# Altium schematic sheet B15.SchDoc(B15)
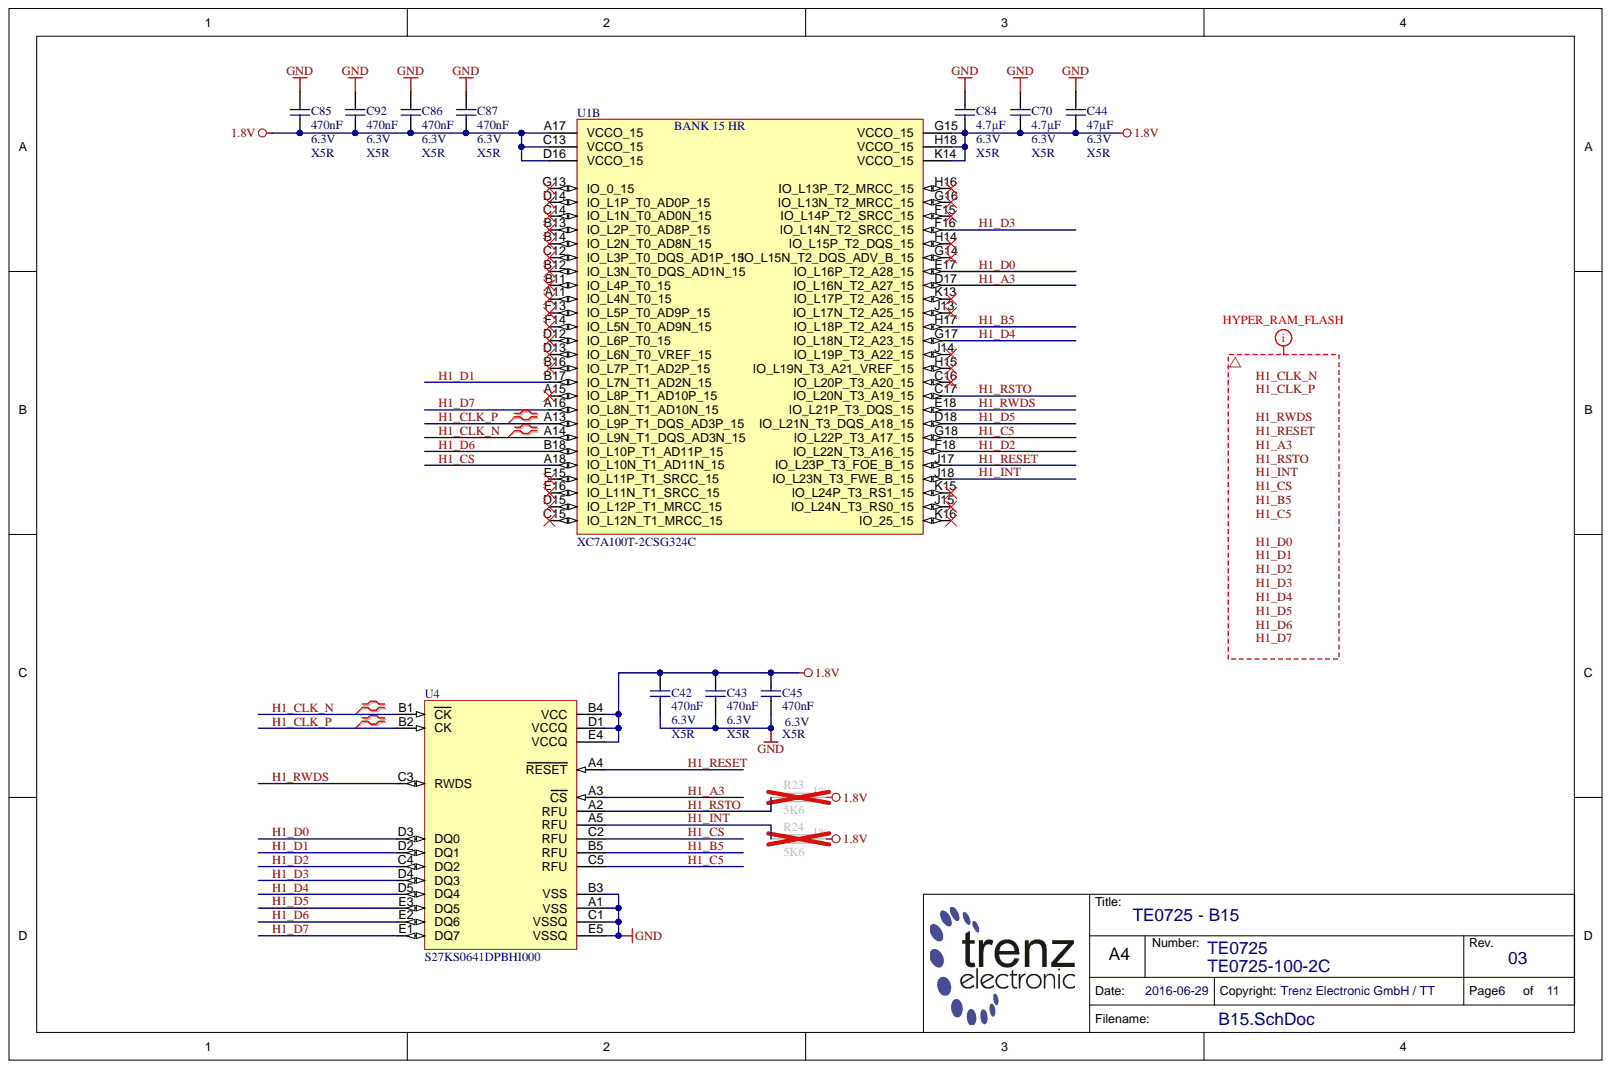
[diagram p0: B15.SchDoc]

## Parts
C42  470nF  Technology: X5R  Voltage: 6.3V  [CAPC0603X33N]
C43  470nF  Technology: X5R  Voltage: 6.3V  [CAPC0603X33N]
C44  47µF  Technology: X5R  Voltage: 6.3V  [CAPC2012X135N]
C45  470nF  Technology: X5R  Voltage: 6.3V  [CAPC0603X33N]
C70  4.7µF  Technology: X5R  Voltage: 6.3V  [CAPC1005X60N]
C84  4.7µF  Technology: X5R  Voltage: 6.3V  [CAPC1005X60N]
C85  470nF  Technology: X5R  Voltage: 6.3V  [CAPC0603X33N]
C86  470nF  Technology: X5R  Voltage: 6.3V  [CAPC0603X33N]
C87  470nF  Technology: X5R  Voltage: 6.3V  [CAPC0603X33N]
C92  470nF  Technology: X5R  Voltage: 6.3V  [CAPC0603X33N]
R23  5K6  Tolerance: 1%  [RESC1005X40N]
R24  5K6  Tolerance: 1%  [RESC1005X40N]
U1  XC7A100T-2CSG324C  [BGA324C80P18X18_1500X1500X150N]  (part B)
U4  S27KS0641DPBHI000  [BGA25C100P5X5_800X600X120N]

## Nets
1.8V: C42.1 C43.1 C44.2 C45.1 C70.2 C84.2 C85.2 C86.2 C87.2 C92.2 R23.2 R24.2 U1.A17(VCCO_15) U1.C13(VCCO_15) U1.D16(VCCO_15) U1.G15(VCCO_15) U1.H18(VCCO_15) U1.K14(VCCO_15) U4.B4(VCC) U4.D1(VCCQ) U4.E4(VCCQ)
GND: C42.2 C43.2 C44.1 C45.2 C70.1 C84.1 C85.1 C86.1 C87.1 C92.1 U4.A1(VSS) U4.B3(VSS) U4.C1(VSSQ) U4.E5(VSSQ)
H1_A3: U1.D17(IO_L16N_T2_A27_15) U4.A3(CS)
H1_B5: U1.H17(IO_L18P_T2_A24_15) U4.B5(RFU)
H1_C5: U1.G18(IO_L22P_T3_A17_15) U4.C5(RFU)
H1_CLK_N: U1.A14(IO_L9N_T1_DQS_AD3N_15) U4.B1(CK)
H1_CLK_P: U1.A13(IO_L9P_T1_DQS_AD3P_15) U4.B2(CK)
H1_CS: U1.A18(IO_L10N_T1_AD11N_15) U4.C2(RFU)
H1_D0: U1.E17(IO_L16P_T2_A28_15) U4.D3(DQ0)
H1_D1: U1.B17(IO_L7N_T1_AD2N_15) U4.D2(DQ1)
H1_D2: U1.F18(IO_L22N_T3_A16_15) U4.C4(DQ2)
H1_D3: U1.F16(IO_L14N_T2_SRCC_15) U4.D4(DQ3)
H1_D4: U1.G17(IO_L18N_T2_A23_15) U4.D5(DQ4)
H1_D5: U1.D18(IO_L21N_T3_DQS_A18_15) U4.E3(DQ5)
H1_D6: U1.B18(IO_L10P_T1_AD11P_15) U4.E2(DQ6)
H1_D7: U1.A16(IO_L8N_T1_AD10N_15) U4.E1(DQ7)
H1_INT: R24.1 U1.J18(IO_L23N_T3_FWE_B_15) U4.A5(RFU)
H1_RESET: U1.J17(IO_L23P_T3_FOE_B_15) U4.A4(RESET)
H1_RSTO: R23.1 U1.C17(IO_L20N_T3_A19_15) U4.A2(RFU)
H1_RWDS: U1.E18(IO_L21P_T3_DQS_15) U4.C3(RWDS)
N00183:

Not connected: U1 A11(IO_L4N_T0_15) A15(IO_L8P_T1_AD10P_15) B11(IO_L4P_T0_15) B12(IO_L3N_T0_DQS_AD1N_15) B13(IO_L2P_T0_AD8P_15) B14(IO_L2N_T0_AD8N_15) B16(IO_L7P_T1_AD2P_15) C12(IO_L3P_T0_DQS_AD1P_15) C14(IO_L1N_T0_AD0N_15) C15(IO_L12N_T1_MRCC_15) C16(IO_L20P_T3_A20_15) D12(IO_L6P_T0_15) D13(IO_L6N_T0_VREF_15) D14(IO_L1P_T0_AD0P_15) D15(IO_L12P_T1_MRCC_15) E15(IO_L11P_T1_SRCC_15) E16(IO_L11N_T1_SRCC_15) F13(IO_L5P_T0_AD9P_15) F14(IO_L5N_T0_AD9N_15) F15(IO_L14P_T2_SRCC_15) G13(IO_0_15) G14(IO_L15N_T2_DQS_ADV_B_15) G16(IO_L13N_T2_MRCC_15) H14(IO_L15P_T2_DQS_15) H15(IO_L19N_T3_A21_VREF_15) H16(IO_L13P_T2_MRCC_15) J13(IO_L17N_T2_A25_15) J14(IO_L19P_T3_A22_15) J15(IO_L24N_T3_RS0_15) K13(IO_L17P_T2_A26_15) K15(IO_L24P_T3_RS1_15) K16(IO_25_15)

## Library data of the parts
C42 C43 C45 C85-C87 C92 = 24921: KON 470nF X5R 6.3V SMD 20%; Database Table Name: C_0603_0201; Mixed: SMT; Preferred: Yes; Value: 470n; AN: 24921; Case: 0603 (0201); Component Kind: Standard; Library Name: TE.DbLib; Tolerance: 20%
C44 = 24718: KON 47µF 6,3V  X5R SMD 20%; Database Table Name: C_2012_0805; Mixed: SMT; Preferred: Yes; Value: 47u; AN: 24718; Case: 2012 (0805); Component Kind: Standard; Library Name: TE.DbLib; Tolerance: 20%
C70 C84 = 24719: KON 4.7µF 6.3V X5R SMD 20%; Database Table Name: C_1005_0402; Mixed: SMT; Preferred: Yes; Value: 4.7u; AN: 24719; Case: 1005 (0402); Component Kind: Standard; Library Name: TE.DbLib; Tolerance: 20%
R23 R24 = 22760: WID SMD 5K6 1% 0,063W; Database Table Name: R_1005_0402; Mixed: SMT; Value: 5.6K; AN: 22760; Case: 1005 (0402); Component Kind: Standard; Library Name: TE.DbLib; Technology: TK100
U1 = 24908: Database Table Name: PROG_LOGIC; Mixed: SMT; Trenz-Stock: Yes; AN: 24908; Case: CSG324; Component Kind: Standard; Description: XC7A100T-2CSG324C; H-ART: XC7A100T-2CSG324C; Library Name: TE.DbLib; HelpURL: B:\AD\adlib\datasheet\XC7
U4 = 26438: S27KS0641DPBHI020; Database Table Name: FLASH; Mixed: SMT; Preferred: Yes; AN: 26438; Case: TFBGA25; Component Kind: Standard; H-ART: S27KS0641DPBHI000; Library Name: TE.DbLib; Trenz-Stock: Yes; HelpURL: B:\ad\adlib\datasheet\S27KS_KL-S
